# Altium schematic sheet [05] Ethernet.SchDoc("[05] Ethernet")
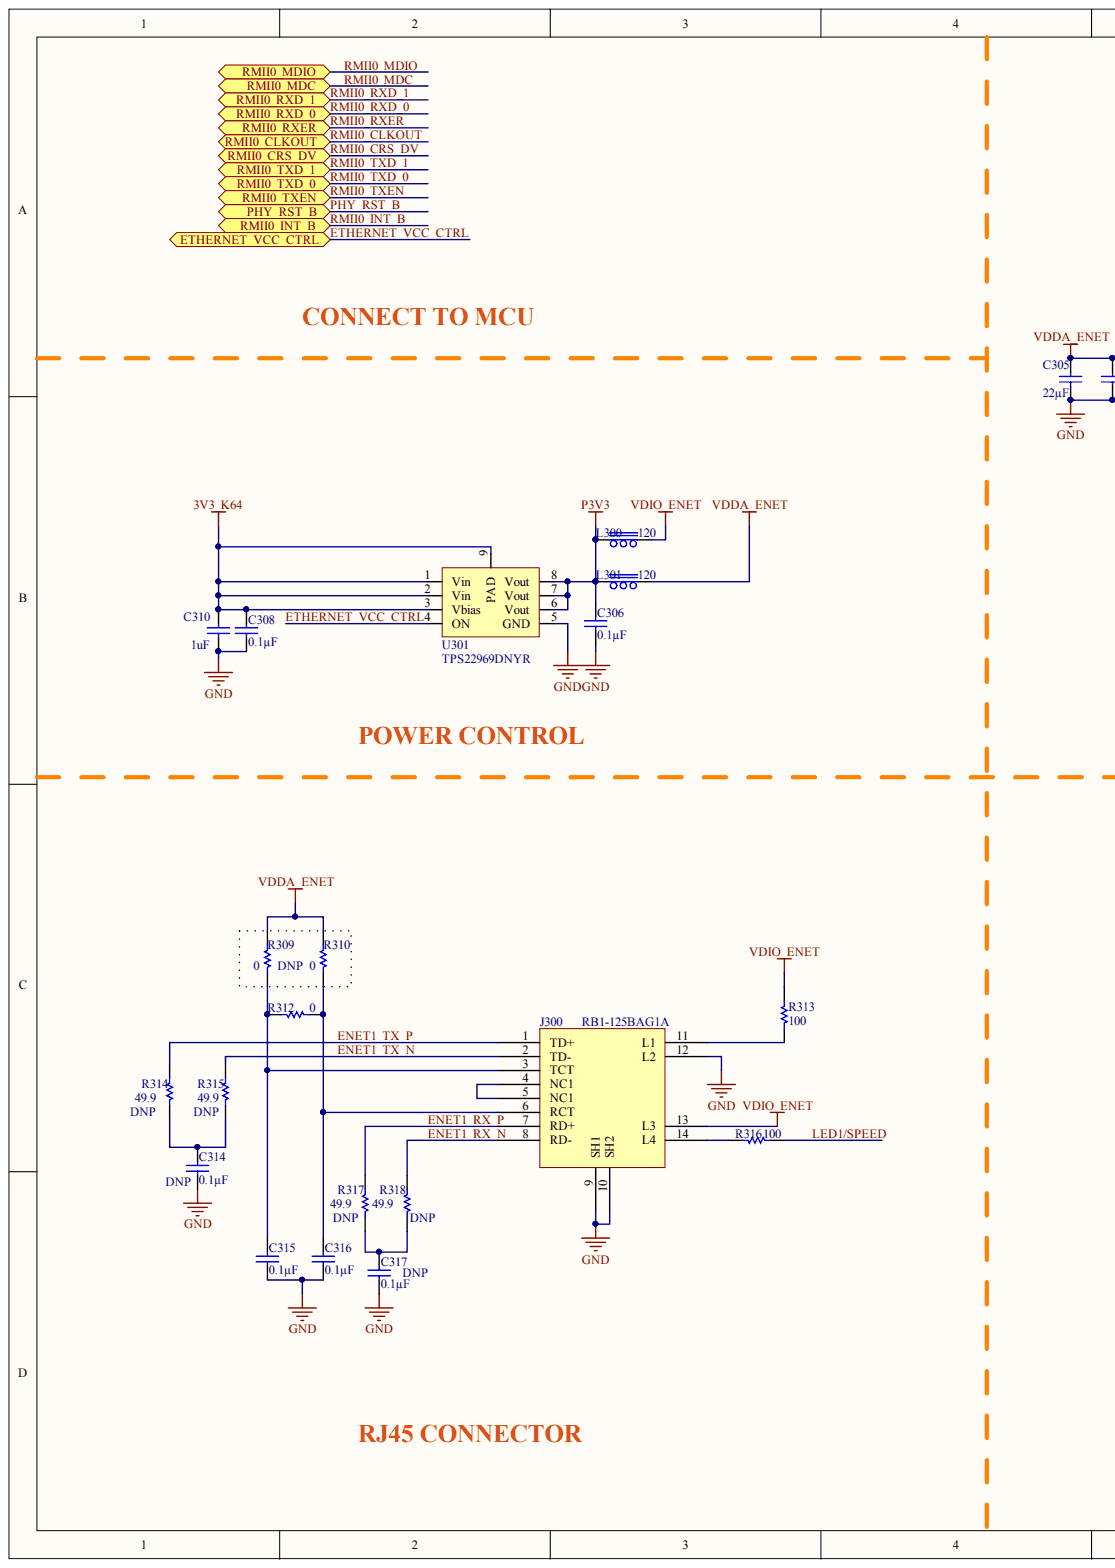
[diagram p0: [05] Ethernet.SchDoc, left]
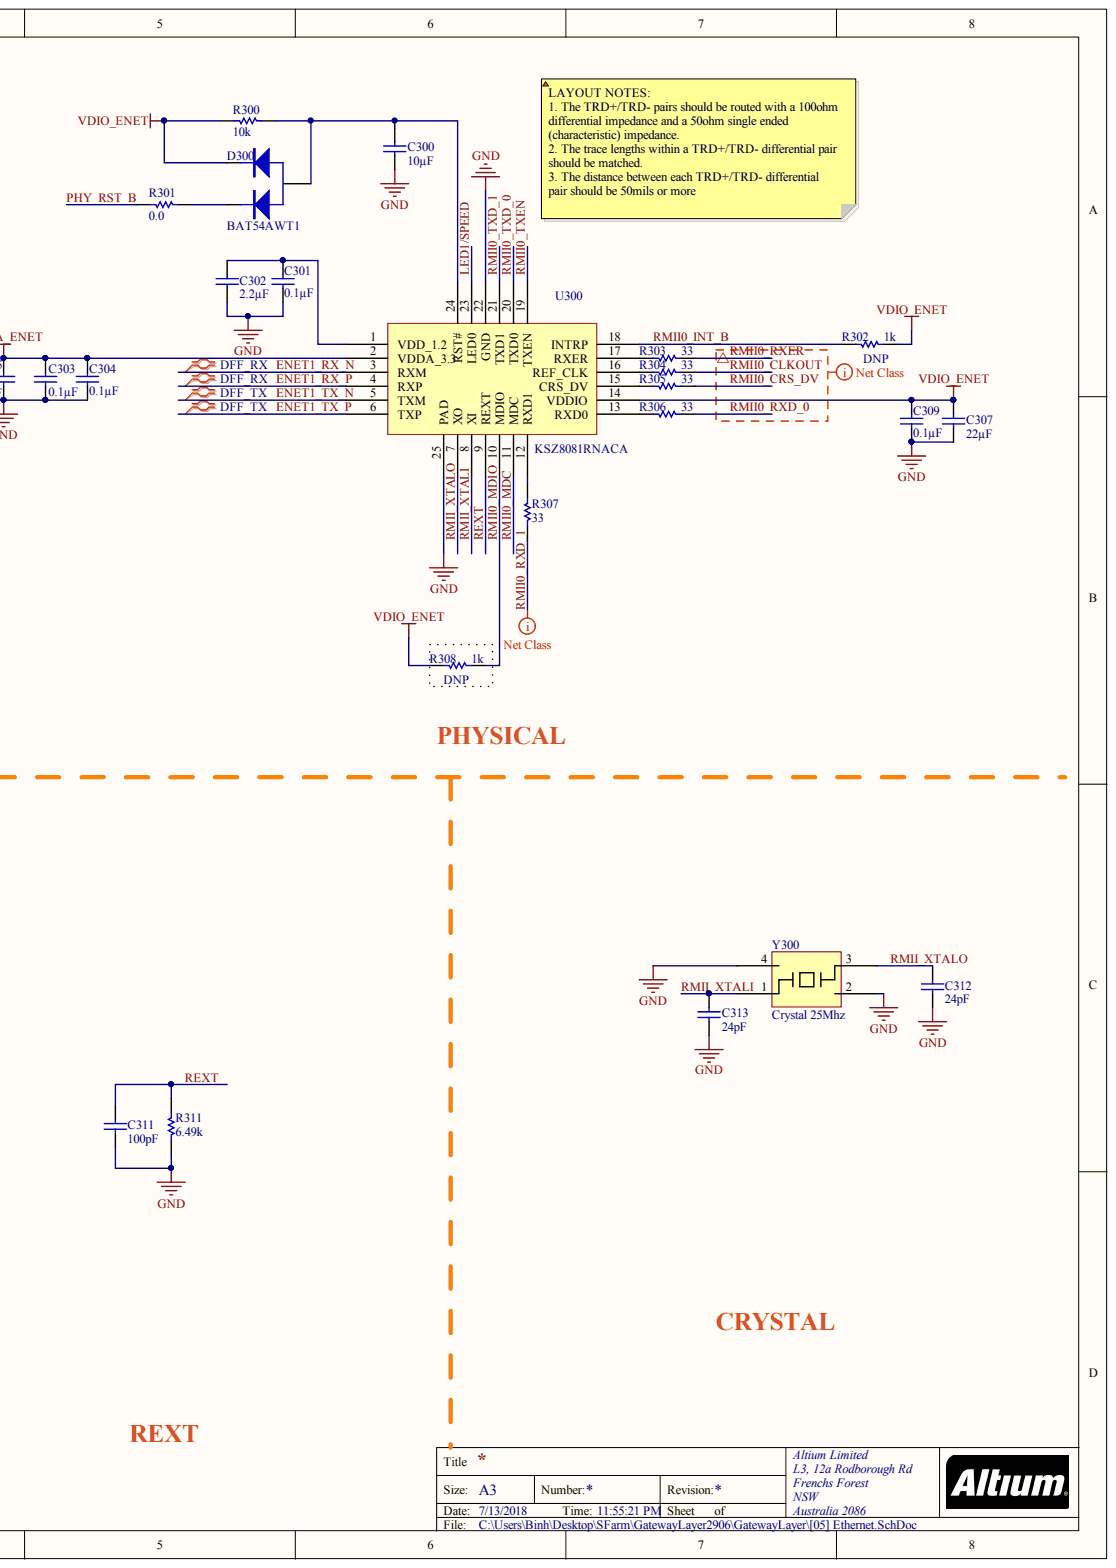
[diagram p1: [05] Ethernet.SchDoc, right]

## Parts
C300  10µF  [0603C]
C301  0.1µF  [0402C]
C302  2.2µF  [0402C]
C303  0.1µF  [0402C]
C304  0.1µF  [0402C]
C305  22µF  [0603C]
C306  0.1µF  [0402C]
C307  22µF  [0603C]
C308  0.1µF  [0402C]
C309  0.1µF  [0402C]
C310  1uF  [0402C]
C311  100pF  [0603C]
C312  24pF  [0402C]
C313  24pF  [0402C]
C314  0.1µF  [0402C]
C315  0.1µF  [0402C]
C316  0.1µF  [0402C]
C317  0.1µF  [0402C]
D300  BAT54AWT1  [SOT323]
J300  RB1-125BAG1A  [RJ45 Tranfomer]
L300  120  [0805F]
L301  120  [0805F]
R300  10k  [0603R]
R301  0.0  [0603R]
R302  1k  [0402R]
R303  33  [0402R]
R304  33  [0402R]
R305  33  [0402R]
R306  33  [0402R]
R307  33  [0402R]
R308  1k  [0402R]
R309  0  [0402R]
R310  0  [0402R]
R311  6.49k  [0603R]
R312  0  [0402R]
R313  100  [0603R]
R314  49.9  [0603R]
R315  49.9  [0603R]
R316  100  [0603R]  (value not drawn)
R317  49.9  [0603R]
R318  49.9  [0603R]
U300  KSZ8081RNACA  [QFN24x]
U301  TPS22969DNYR  [WSON8]
Y300  Crystal 25Mhz  [3225 cry]

## Ports
ETHERNET_VCC_CTRL, PHY_RST_B, RMII0_CLKOUT, RMII0_CRS_DV, RMII0_INT_B, RMII0_MDC, RMII0_MDIO, RMII0_RXD_0, RMII0_RXD_1, RMII0_RXER, RMII0_TXD_0, RMII0_TXD_1, RMII0_TXEN

## Nets
3V3_K64: C308.2 C310.2 U301.1(Vin) U301.2(Vin) U301.3(Vbias) U301.9(PAD)
GND: C300.1 C301.1 C302.1 C303.1 C304.1 C305.1 C306.1 C307.1 C308.1 C309.1 C310.1 C311.1 C312.1 C313.1 C314.1 C315.1 C316.1 C317.1 J300.9(SH1) J300.10(SH2) J300.12(L2) R311.1 U300.22(GND) U300.25(PAD) U301.5(GND) Y300.2 Y300.4
P3V3: C306.2 L300.1 L301.1 U301.6(Vout) U301.7(Vout) U301.8(Vout)
VDDA_ENET: C303.2 C304.2 C305.2 L301.2 R309.2 R310.2 U300.2(VDDA_3.3)
VDIO_ENET: C307.2 C309.2 D300.1 J300.13(L3) L300.2 R300.1 R302.2 R308.1 R313.2 U300.14(VDDIO)
ENET1_RX_N: J300.8(RD-) R318.2 U300.3(RXM)
ENET1_RX_P: J300.7(RD+) R317.2 U300.4(RXP)
ENET1_TX_N: J300.2(TD-) R315.2 U300.5(TXM)
ENET1_TX_P: J300.1(TD+) R314.2 U300.6(TXP)
ETHERNET_VCC_CTRL: U301.4(ON) ; port ETHERNET_VCC_CTRL
LED1/SPEED: R316.2 U300.23(LED0)
PHY_RST_B: R301.1 ; port PHY_RST_B
REXT: C311.2 R311.2 U300.9(REXT)
RMII0_CLKOUT: R304.2 ; port RMII0_CLKOUT
RMII0_CRS_DV: R305.2 ; port RMII0_CRS_DV
RMII0_INT_B: R302.1 U300.18(INTRP) ; port RMII0_INT_B
RMII0_MDC: U300.11(MDC) ; port RMII0_MDC
RMII0_MDIO: R308.2 U300.10(MDIO) ; port RMII0_MDIO
RMII0_RXD_0: R306.2 ; port RMII0_RXD_0
RMII0_RXD_1: R307.1 ; port RMII0_RXD_1
RMII0_RXER: R303.2 ; port RMII0_RXER
RMII0_TXD_0: U300.20(TXD0) ; port RMII0_TXD_0
RMII0_TXD_1: U300.21(TXD1) ; port RMII0_TXD_1
RMII0_TXEN: U300.19(TXEN) ; port RMII0_TXEN
RMII_XTALI: C313.2 U300.8(XI) Y300.1
RMII_XTALO: C312.2 U300.7(XO) Y300.3
NetC300_2: C300.2 D300.3 R300.2 U300.24(RST#)
NetC301_2: C301.2 C302.2 U300.1(VDD_1.2)
NetC314_2: C314.2 R314.1 R315.1
NetC315_2: C315.2 J300.3(TCT) R309.1 R312.2
NetC316_2: C316.2 J300.6(RCT) R310.1 R312.1
NetC317_2: C317.2 R317.1 R318.1
NetD300_2: D300.2 R301.2
NetJ300_4: J300.4(NC1) J300.5(NC1)
NetJ300_11: J300.11(L1) R313.1
NetJ300_14: J300.14(L4) R316.1
NetR303_1: R303.1 U300.17(RXER)
NetR304_1: R304.1 U300.16(REF_CLK)
NetR305_1: R305.1 U300.15(CRS_DV)
NetR306_1: R306.1 U300.13(RXD0)
NetR307_2: R307.2 U300.12(RXD1)
N00117:

## Library data of the parts
C300 = cap SMD: CAP CER 10UF 16V X6S 0603; Manufacturer: Taiyo Yuden; Manufacturer Part Number: EMK107BC6106MA-T; Tolerance: ±20%; Applications: SMPS Filtering; Category: Capacitors; Component Kind: Standard; ComponentLink1Description: http://www.yuden.co.jp/productdata/catalog/en/mlcc06_e.pdf; ComponentLink1URL: http://www.yuden.co.jp/productdata/catalog/en/mlcc06_e.pdf; Failure Rate: -; Features: -; Height - Seated (Max): -; Lead Spacing: -; Lead Style: -; Mounting Type: Surface Mount, MLCC; Operating Temperature: -55°C ~ 105°C; Package / Case: 0603 (1608 Metric); Packaging: Cut Tape (CT); Part Status: Active; Pricing 1: 1=0.46, 10=0.32, 100=0.192, 500=0.14402, 1000=0.12162 (USD); Ratings: -; RoHS: RoHS Compliant; Size / Dimension: 0.063" L x 0.031" W (1.60mm x 0.80mm); Stock 1: 23688; Supplier 1: Digi-Key; Supplier Part Number 1: 587-4867-1-ND; Temperature Coefficient: X6S; Thickness (Max): 0.039" (1.00mm); Voltage - Rated: 16V; Library Name: BinhLib.IntLib
C301 C303 C304 C306 C308 C309 C314-C317 = CAP0.1u0402: CAP CER 0.1UF 10V X7R 0402; Manufacturer: Taiyo Yuden; Manufacturer Part Number: LMK105B7104KVHF; Tolerance: ±10%; Applications: Automotive; Capacitance: 0.1µF; Category: Capacitors; Component Kind: Standard; ComponentLink1Description: http://www.yuden.co.jp/productdata/catalog/en/mlcc_all_hq_e.pdf; ComponentLink1URL: http://www.yuden.co.jp/productdata/catalog/en/mlcc_all_hq_e.pdf; Features: -; Height - Seated (Max): -; Lead Spacing: -; Lead Style: -; Mounting Type: Surface Mount, MLCC; Operating Temperature: -55°C ~ 125°C; Package / Case: 0402 (1005 Metric); Packaging: Cut Tape (CT); Part Status: Active; Pricing 1: 1=0.1, 10=0.05, 100=0.0223, 500=0.01594, 1000=0.01252, 2500=0.01138, 5000=0.01047 (USD); Ratings: AEC-Q200; RoHS: RoHS Compliant; Size / Dimension: 0.039" L x 0.020" W (1.00mm x 0.50mm); Stock 1: 23930; Supplier 1: Digi-Key; Supplier Part Number 1: 587-3769-1-ND; Temperature Coefficient: X7R; Thickness (Max): 0.022" (0.55mm); Voltage - Rated: 10V; Library Name: BinhLib.IntLib
C302 = cap2.2u0402: CAP CER 2.2UF 16V X5R 0402; Manufacturer: Taiyo Yuden; Manufacturer Part Number: EMK105ABJ225MV-F; Tolerance: ±20%; Applications: General Purpose; Capacitance: 2.2µF; Category: Capacitors; Component Kind: Standard; ComponentLink1Description: http://ds.yuden.co.jp/TYCOMPAS/ut/detail.do?productNo=EMK105ABJ225MV-F&fileName=EMK105ABJ225MV-F_SS&mode=specSheetDownload; ComponentLink1URL: http://ds.yuden.co.jp/TYCOMPAS/ut/detail.do?productNo=EMK105ABJ225MV-F&fileName=EMK105ABJ225MV-F_SS&mode=specSheetDownload; Features: -; Height - Seated (Max): -; Lead Spacing: -; Lead Style: -; Mounting Type: Surface Mount, MLCC; Operating Temperature: -55°C ~ 85°C; Package / Case: 0402 (1005 Metric); Packaging: Cut Tape (CT); Part Status: Active; Pricing 1: 1=0.27, 10=0.187, 100=0.1062, 500=0.07498, 1000=0.0656, 2500=0.06248, 5000=0.05779 (USD); Ratings: -; RoHS: RoHS Compliant; Size / Dimension: 0.039" L x 0.020" W (1.00mm x 0.50mm); Stock 1: 43595; Supplier 1: Digi-Key; Supplier Part Number 1: 587-3302-1-ND; Temperature Coefficient: X5R; Thickness (Max): 0.022" (0.55mm); Voltage - Rated: 16V; Library Name: BinhLib.IntLib
C305 C307 = cap SMD: CAP CER 22UF 10V X5R 0603; Manufacturer: Taiyo Yuden; Manufacturer Part Number: LMK107BBJ226MA-T; Tolerance: ±20%; Applications: SMPS Filtering; Category: Capacitors; Component Kind: Standard; ComponentLink1Description: http://ds.yuden.co.jp/TYCOMPAS/ut/detail.do?productNo=LMK107BBJ226MA-T&fileName=LMK107BBJ226MA-T_SS&mode=specSheetDownload; ComponentLink1URL: http://ds.yuden.co.jp/TYCOMPAS/ut/detail.do?productNo=LMK107BBJ226MA-T&fileName=LMK107BBJ226MA-T_SS&mode=specSheetDownload; Failure Rate: -; Features: -; Height - Seated (Max): -; Lead Spacing: -; Lead Style: -; Mounting Type: Surface Mount, MLCC; Operating Temperature: -55°C ~ 85°C; Package / Case: 0603 (1608 Metric); Packaging: Cut Tape (CT); Part Status: Active; Pricing 1: 1=0.49, 10=0.345, 100=0.2271, 500=0.16826, 1000=0.14302 (USD); Ratings: -; RoHS: RoHS Compliant; Size / Dimension: 0.063" L x 0.031" W (1.60mm x 0.80mm); Stock 1: 11956; Supplier 1: Digi-Key; Supplier Part Number 1: 587-4913-1-ND; Temperature Coefficient: X5R; Thickness (Max): 0.039" (1.00mm); Voltage - Rated: 10V; Library Name: BinhLib.IntLib
C310 = cap SMD: CAP CER 1UF 10V X6S 0402; Manufacturer: Taiyo Yuden; Manufacturer Part Number: LMK105C6105KV-F; Tolerance: ±10%; Applications: General Purpose; Category: Capacitors; Component Kind: Standard; ComponentLink1Description: http://www.yuden.co.jp/productdata/catalog/en/mlcc01_e.pdf; ComponentLink1URL: http://www.yuden.co.jp/productdata/catalog/en/mlcc01_e.pdf; Features: -; Height - Seated (Max): -; Lead Spacing: -; Lead Style: -; Mounting Type: Surface Mount, MLCC; Operating Temperature: -55°C ~ 105°C; Package / Case: 0402 (1005 Metric); Packaging: Cut Tape (CT); Part Status: Active; Pricing 1: 1=0.13, 10=0.096, 100=0.0451, 500=0.03164, 1000=0.02619, 2500=0.02438, 5000=0.0223 (USD); Ratings: -; RoHS: RoHS Compliant; Size / Dimension: 0.039" L x 0.020" W (1.00mm x 0.50mm); Stock 1: 11404; Supplier 1: Digi-Key; Supplier Part Number 1: 587-3409-1-ND; Temperature Coefficient: X6S; Thickness (Max): 0.022" (0.55mm); Voltage - Rated: 10V; Library Name: BinhLib.IntLib
C311 = cap SMD: CAP CER 100PF 250V NP0 0603; Manufacturer: Taiyo Yuden; Manufacturer Part Number: QVS107CG101JCHT; Temperature Coefficient: C0G, NP0; Tolerance: ±5%; Applications: Automotive; Capacitance: 100pF; Category: Capacitors; Component Kind: Standard; Family: Ceramic Capacitors; Features: -; Height - Seated (Max): -; Lead Spacing: -; Lead Style: -; Mounting Type: Surface Mount, MLCC; Operating Temperature: -55°C ~ 125°C; Package / Case: 0603 (1608 Metric); Packaging: Tape &amp; Reel (TR); Pricing 1: 1=0.71, 10=0.598, 50=0.4286, 100=0.3751, 250=0.2947, 500=0.2679, 1000=0.2143 (USD); Ratings: -; Series: V; Size / Dimension: 0.063" L x 0.031" W (1.60mm x 0.80mm); Stock 1: 7748; Supplier 1: Digikey; Supplier Part Number 1: 587-4439-6-ND; Thickness (Max): 0.031" (0.80mm); Voltage - Rated: 250V; Library Name: BinhLib.IntLib
C312 C313 = cap SMD: CAP CER 24PF 50V NP0 0402; Manufacturer: Taiyo Yuden; Manufacturer Part Number: UMK105CG240JV-F; Temperature Coefficient / Code: C0G; Tolerance: 5 %; Capacitance: 24 pF; Case Code - in: 0402; Case Code - mm: 1005; Component Kind: Standard; ComponentLink1Description: http://www.mouser.com/ds/2/396/mlcc02_e-9198.pdf; ComponentLink1URL: http://www.mouser.com/ds/2/396/mlcc02_e-9198.pdf; Dimensions: 0.5 mm W x 1 mm L x 0.5 mm H; Operating Temperature Range: - 55 C to + 125 C; Package / Case: 0402 (1005 metric); Packaging: Reel; Pricing 1: 10000=0.0034 (USD); Product: General Type MLCCs; RoHS: Details; Series: M; Stock 1: 0; Supplier 1: Digikey; Supplier Part Number 1: UMK105CG240JV-F-ND; Termination Style: SMD/SMT; Voltage Rating: 50 V; Library Name: BinhLib.IntLib
D300 = diodes barrier: DIODE ARRAY SCHOTTKY 30V SOT323; Manufacturer: ON Semiconductor; Manufacturer Part Number: BAT54AWT1G; Current - Average Rectified (Io) (per Diode): 200mA (DC); Current - Reverse Leakage @ Vr: 2µA @ 25V; Diode Configuration: 1 Pair Common Anode; Speed: Small Signal =< 200mA (Io), Any Speed; Voltage - Forward (Vf) (Max) @ If: 800mV @ 100mA; Category: Discrete Semiconductor Products; Component Kind: Standard; ComponentLink1Description: http://www.onsemi.com/pub_link/Collateral/BAT54AWT1-D.PDF; ComponentLink1URL: http://www.onsemi.com/pub_link/Collateral/BAT54AWT1-D.PDF; Diode Type: Schottky; Mounting Type: Surface Mount; Operating Temperature - Junction: -55°C ~ 125°C; Package / Case: SC-70, SOT-323; Packaging: Cut Tape (CT); Part Status: Active; Pricing 1: 1=0.3, 10=0.248, 100=0.1313, 500=0.08638, 1000=0.05874 (USD); RoHS: RoHS Compliant; Stock 1: 0; Supplier 1: Digi-Key; Supplier Device Package: SOT-323; Supplier Part Number 1: BAT54AWT1GOSCT-ND; Library Name: BinhLib.IntLib
J300 = RJ45: CONN MAGJACK 1PORT 100 BASE-T; Manufacturer: WIZnet; LED Color: Green - Yellow; Number of Cores per Jack: 4; Number of Ports: 1; Other Names: 1278-1011  RB1125BAG1A; Shielding: Shielded, EMI Finger; Shield Material: Stainless Steel; Tab Direction: Down; Applications: 10/100 Base-T, AutoMDIX; Category: Connectors, Interconnects; Component Kind: Standard; ComponentLink1Description: https://datasheet.ciiva.com/16480/rb1-125bag1a-16480451.pdf; ComponentLink1URL: https://datasheet.ciiva.com/16480/rb1-125bag1a-16480451.pdf; ComponentLink2Description: https://datasheet.ciiva.com/19455/2132875-19455478.pdf; ComponentLink2URL: https://datasheet.ciiva.com/19455/2132875-19455478.pdf; ComponentLink3Description: https://datasheet.ciiva.com/6930/rb1-125bag1a-approvalsheet-6930969.pdf; ComponentLink3URL: https://datasheet.ciiva.com/6930/rb1-125bag1a-approvalsheet-6930969.pdf; ComponentLink4Description: https://datasheet.ciiva.com/15367/rb1-125bag1a111-00-224696-15367787.pdf; ComponentLink4URL: https://datasheet.ciiva.com/15367/rb1-125bag1a111-00-224696-15367787.pdf; Connector Type: RJ45; Contact Finish: Gold; Contact Finish Thickness: Flash; Contact Material: Phosphor Bronze; Family: Modular Connectors - Jacks With Magnetics; Features: Board Lock; Height Above Board: 0.525" (13.33mm); Housing Material: Thermoplastic; Manufacturer Part Number: RB1-125BAG1A; Mounting Type: Through Hole; Number of Rows: 1; Online Catalog: WIZnet; Operating Temperature: 0°C ~ 70°C; Orientation: 90° Angle (Right); Packaging: Bulk; Pricing 1: 1=2.55, 10=2.448, 25=2.244, 50=2.142, 100=2.04, 250=1.785, 500=1.734, 1000=1.56 (USD); Series: -; Standard Package: 420; Stock 1: 25601; Supplier 1: Digikey; Supplier Part Number 1: 1278-1011-ND; Termination: Solder; Library Name: BinhLib.IntLib
L300 L301 = Ferrite Bead: Manufacturer: MURATA; Manufacturer Part Number: BLM18PG121SN1D; DC Current Rating: 2; DC Resistance Max: 0.05; Ferrite Case Style: 0603 [1608 Metric]; Impedance Tolerance: 25%; Product Range: BLM18; Automotive Qualifications Standard: -; Component Kind: Standard; ComponentLink1Description: http://www.farnell.com/datasheets/1740433.pdf; ComponentLink1URL: http://www.farnell.com/datasheets/1740433.pdf; ComponentLink2Description: http://www.farnell.com/datasheets/5148.pdf; ComponentLink2URL: http://www.farnell.com/datasheets/5148.pdf; Packaging: Each; Pricing 1: 1=0.039, 50=0.036, 100=0.029, 500=0.026, 1000=0.023, 2500=0.022, 5000=0.02, 10000=0.019 (USD); RoHS: YES; Stock 1: 28827; Supplier 1: Newark; Supplier Part Number 1: 73M9109; Library Name: BinhLib.IntLib
R300 = RES10k0603: RES SMD 10K OHM 1% 1/10W 0603; Manufacturer: Panasonic Electronic Components; Manufacturer Part Number: ERJ-3EKF1002V; Power (Watts): 0.1W, 1/10W; Temperature Coefficient: ±100ppm/°C; Category: Resistors; Component Kind: Standard; ComponentLink1Description: https://industrial.panasonic.com/cdbs/www-data/pdf/RDA0000/AOA0000C298.pdf; ComponentLink1URL: https://industrial.panasonic.com/cdbs/www-data/pdf/RDA0000/AOA0000C298.pdf; Composition: Thick Film; Failure Rate: -; Features: Automotive AEC-Q200; Height - Seated (Max): 0.022" (0.55mm); Operating Temperature: -55°C ~ 155°C; Package / Case: 0603 (1608 Metric); Packaging: Digi-Reel®; Part Status: Active; Pricing 1: 1=0.1, 10=0.021, 100=0.0087, 1000=0.00389, 2500=0.00338 (USD); Resistance (Ohms): 10k; RoHS: RoHS Compliant; Size / Dimension: 0.063" L x 0.031" W (1.60mm x 0.80mm); Stock 1: 0; Supplier 1: Digi-Key; Supplier Device Package: 0603; Supplier Part Number 1: P10.0KHDKR-ND; Tolerance: ±1%; Library Name: BinhLib.IntLib
R301 = RES00603: RES SMD 0.0OHM JUMPER 1/10W 0603; Manufacturer: Panasonic Electronic Components; Manufacturer Part Number: ERJ-3GEY0R00V; Power (Watts): 0.1W, 1/10W; Category: Resistors; Component Kind: Standard; ComponentLink1Description: http://industrial.panasonic.com/www-cgi/jvcr13pz.cgi?E+PZ+3+AOA0001+ERJ3GEY0R00V+7+WW; ComponentLink1URL: http://industrial.panasonic.com/www-cgi/jvcr13pz.cgi?E+PZ+3+AOA0001+ERJ3GEY0R00V+7+WW; Composition: Thick Film; Failure Rate: -; Features: Automotive AEC-Q200; Height - Seated (Max): 0.022" (0.55mm); Operating Temperature: -55°C ~ 155°C; Package / Case: 0603 (1608 Metric); Packaging: Cut Tape (CT); Part Status: Active; Pricing 1: 1=0.1, 10=0.016, 25=0.0116, 50=0.0088, 100=0.0065, 250=0.00496, 500=0.00396, 1000=0.00292 (USD); Resistance (Ohms): 0.0; RoHS: RoHS Compliant; Size / Dimension: 0.063" L x 0.031" W (1.60mm x 0.80mm); Stock 1: 8597757; Supplier 1: Digi-Key; Supplier Device Package: 0603; Supplier Part Number 1: P0.0GCT-ND; Temperature Coefficient: -; Tolerance: Jumper; Library Name: BinhLib.IntLib
R302 R308 = RES1k0402: RES SMD 1K OHM 1% 1/10W 0402; Manufacturer: Panasonic Electronic Components; Manufacturer Part Number: ERJ-2RKF1001X; Power (Watts): 0.1W, 1/10W; Temperature Coefficient: ±100ppm/°C; Category: Resistors; Component Kind: Standard; ComponentLink1Description: https://industrial.panasonic.com/cdbs/www-data/pdf/RDA0000/AOA0000C298.pdf; ComponentLink1URL: https://industrial.panasonic.com/cdbs/www-data/pdf/RDA0000/AOA0000C298.pdf; Composition: Thick Film; Failure Rate: -; Features: Automotive AEC-Q200; Height - Seated (Max): 0.016" (0.40mm); Operating Temperature: -55°C ~ 155°C; Package / Case: 0402 (1005 Metric); Packaging: Cut Tape (CT); Part Status: Active; Pricing 1: 1=0.1, 10=0.028, 100=0.0113, 1000=0.00509, 2500=0.00442, 5000=0.00365 (USD); Resistance (Ohms): 1k; RoHS: RoHS Compliant; Size / Dimension: 0.039" L x 0.020" W (1.00mm x 0.50mm); Stock 1: 0; Supplier 1: Digi-Key; Supplier Device Package: 0402; Supplier Part Number 1: P1.00KLCT-ND; Tolerance: ±1%; Library Name: BinhLib.IntLib
R303-R307 = RES330402: RES SMD 33 OHM 1% 1/10W 0402; Manufacturer: Panasonic Electronic Components; Manufacturer Part Number: ERJ-2RKF33R0X; Power (Watts): 0.1W, 1/10W; Temperature Coefficient: ±100ppm/°C; Category: Resistors; Component Kind: Standard; ComponentLink1Description: http://media.digikey.com/pdf/Data%20Sheets/Panasonic%20Electronic%20Components/ERJ%20Series_Precision.pdf; ComponentLink1URL: http://media.digikey.com/pdf/Data%20Sheets/Panasonic%20Electronic%20Components/ERJ%20Series_Precision.pdf; Composition: Thick Film; Failure Rate: -; Features: Automotive AEC-Q200; Height - Seated (Max): 0.016" (0.40mm); Operating Temperature: -55°C ~ 155°C; Package / Case: 0402 (1005 Metric); Packaging: Cut Tape (CT); Part Status: Active; Pricing 1: 1=0.1, 10=0.028, 100=0.0113, 1000=0.00509, 2500=0.00442, 5000=0.00365 (USD); Resistance (Ohms): 33; RoHS: RoHS Compliant; Size / Dimension: 0.039" L x 0.020" W (1.00mm x 0.50mm); Stock 1: 104778; Supplier 1: Digi-Key; Supplier Device Package: 0402; Supplier Part Number 1: P33.0LCT-ND; Tolerance: ±1%; Library Name: BinhLib.IntLib
R309 R310 R312 = RES00603: RES SMD 0.0OHM JUMPER 1/10W 0402; Manufacturer: Panasonic Electronic Components; Manufacturer Part Number: ERJ-2GE0R00X; Power (Watts): 0.1W, 1/10W; Category: Resistors; Component Kind: Standard; ComponentLink1Description: http://industrial.panasonic.com/www-cgi/jvcr13pz.cgi?E+PZ+3+AOA0001+ERJ2GE0R00X+7+WW; ComponentLink1URL: http://industrial.panasonic.com/www-cgi/jvcr13pz.cgi?E+PZ+3+AOA0001+ERJ2GE0R00X+7+WW; Composition: Thick Film; Failure Rate: -; Features: Automotive AEC-Q200; Height - Seated (Max): 0.016" (0.40mm); Operating Temperature: -55°C ~ 155°C; Package / Case: 0402 (1005 Metric); Packaging: Cut Tape (CT); Part Status: Active; Pricing 1: 1=0.1, 10=0.016, 25=0.0116, 50=0.0088, 100=0.0065, 250=0.00496, 500=0.00396, 1000=0.00292, 5000=0.00209 (USD); RoHS: RoHS Compliant; Size / Dimension: 0.039" L x 0.020" W (1.00mm x 0.50mm); Stock 1: 3982454; Supplier 1: Digi-Key; Supplier Device Package: 0402; Supplier Part Number 1: P0.0JCT-ND; Temperature Coefficient: -; Tolerance: Jumper; Library Name: BinhLib.IntLib
R311 = RES1000603: RES SMD 6.49KOHM 0.1% 1/10W 0603; Manufacturer: Panasonic Electronic Components; Manufacturer Part Number: ERA-3AEB6491V; Power (Watts): 0.1W, 1/10W; Temperature Coefficient: ±25ppm/°C; Category: Resistors; Component Kind: Standard; ComponentLink1Description: https://industrial.panasonic.com/cdbs/www-data/pdf/RDM0000/AOA0000C307.pdf; ComponentLink1URL: https://industrial.panasonic.com/cdbs/www-data/pdf/RDM0000/AOA0000C307.pdf; Composition: Thin Film; Failure Rate: -; Features: Automotive AEC-Q200; Height - Seated (Max): 0.022" (0.55mm); Operating Temperature: -55°C ~ 155°C; Package / Case: 0603 (1608 Metric); Packaging: Cut Tape (CT); Part Status: Active; Pricing 1: 1=0.35, 10=0.3, 100=0.1172, 1000=0.04906, 2500=0.04498 (USD); Resistance (Ohms): 6.49k; RoHS: RoHS Compliant; Size / Dimension: 0.063" L x 0.031" W (1.60mm x 0.80mm); Stock 1: 16504; Supplier 1: Digi-Key; Supplier Device Package: 0603; Supplier Part Number 1: P6.49KDBCT-ND; Tolerance: ±0.1%; Library Name: BinhLib.IntLib
R313 R316 = RES1000603: RES SMD 100 OHM 1% 1/10W 0603; Manufacturer: Panasonic Electronic Components; Manufacturer Part Number: ERJ-3EKF1000V; Power (Watts): 0.1W, 1/10W; Temperature Coefficient: ±100ppm/°C; Category: Resistors; Component Kind: Standard; ComponentLink1Description: https://industrial.panasonic.com/cdbs/www-data/pdf/RDA0000/AOA0000C298.pdf; ComponentLink1URL: https://industrial.panasonic.com/cdbs/www-data/pdf/RDA0000/AOA0000C298.pdf; Composition: Thick Film; Failure Rate: -; Features: Automotive AEC-Q200; Height - Seated (Max): 0.022" (0.55mm); Operating Temperature: -55°C ~ 155°C; Package / Case: 0603 (1608 Metric); Packaging: Cut Tape (CT); Part Status: Active; Pricing 1: 1=0.1, 10=0.021, 100=0.0087, 1000=0.00389, 2500=0.00338 (USD); Resistance (Ohms): 100; RoHS: RoHS Compliant; Size / Dimension: 0.063" L x 0.031" W (1.60mm x 0.80mm); Stock 1: 648504; Supplier 1: Digi-Key; Supplier Device Package: 0603; Supplier Part Number 1: P100HCT-ND; Tolerance: ±1%; Library Name: BinhLib.IntLib
R314 R315 R317 R318 = Resistor: RES SMD 49.9 OHM 1% 1/10W 0603; Manufacturer: Panasonic Electronic Components; Manufacturer Part Number: ERJ-3EKF49R9V; Power (Watts): 0.1W, 1/10W; Temperature Coefficient: ±100ppm/°C; Category: Resistors; Component Kind: Standard; ComponentLink1Description: http://media.digikey.com/pdf/Data%20Sheets/Panasonic%20Electronic%20Components/ERJ%20Series_Precision.pdf; ComponentLink1URL: http://media.digikey.com/pdf/Data%20Sheets/Panasonic%20Electronic%20Components/ERJ%20Series_Precision.pdf; Composition: Thick Film; Failure Rate: -; Features: Automotive AEC-Q200; Height - Seated (Max): 0.022" (0.55mm); Operating Temperature: -55°C ~ 155°C; Package / Case: 0603 (1608 Metric); Packaging: Cut Tape (CT); Part Status: Active; Pricing 1: 1=0.1, 10=0.021, 100=0.0087, 1000=0.00389, 2500=0.00338 (USD); Resistance (Ohms): 49.9; RoHS: RoHS Compliant; Size / Dimension: 0.063" L x 0.031" W (1.60mm x 0.80mm); Stock 1: 0; Supplier 1: Digi-Key; Supplier Device Package: 0603; Supplier Part Number 1: P49.9HCT-ND; Tolerance: ±1%; Library Name: BinhLib.IntLib
U300 = KSZ8081RNACA: IC TXRX ETHERNET 24QFN; Manufacturer: Microchip Technology; Manufacturer Part Number: KSZ8081RNACA-TR; Duplex: Full; Number of Drivers/Receivers: 1/1; Protocol: RMII; Voltage - Supply: 1.8V, 2.5V, 3.3V; Category: Integrated Circuits (ICs); Component Kind: Standard; ComponentLink1Description: http://www.microchip.com/mymicrochip/filehandler.aspx?ddocname=en581592; ComponentLink1URL: http://www.microchip.com/mymicrochip/filehandler.aspx?ddocname=en581592; Data Rate: -; Mounting Type: Surface Mount; Operating Temperature: 0°C ~ 70°C; Package / Case: 24-VFQFN Exposed Pad; Packaging: Cut Tape (CT); Part Status: Active; Pricing 1: 1=1.04, 25=0.8652, 100=0.8613 (USD); RoHS: RoHS Compliant; Stock 1: 26739; Supplier 1: Digi-Key; Supplier Device Package: 24-QFN (4x4); Supplier Part Number 1: 576-4173-1-ND; Type: Transceiver; Library Name: BinhLib.IntLib
U301 = TPS22969: IC LOAD SWITCH 6A 8WSON; Manufacturer: Texas Instruments; Input Type: Non-Inverting; Interface: On/Off; Number of Outputs: 1; Output Configuration: High Side; Output Type: N-Channel; Ratio - Input: 1:1; Rds On (Typ): 4.4 mOhm; Switch Type: General Purpose; Voltage - Load: 2.5 V ~ 5.5 V; Voltage - Supply (Vcc/Vdd): 0.8 V ~ 5.5 V; Category: Integrated Circuits (ICs); Component Kind: Standard; ComponentLink1Description: http://www.ti.com/lit/ds/symlink/tps22969.pdf; ComponentLink1URL: http://www.ti.com/lit/ds/symlink/tps22969.pdf; Current - Output (Max): 6A; Fault Protection: -; Features: Load Discharge, Slew Rate Controlled; Manufacturer Part Number: TPS22969DNYR; Operating Temperature: -40°C ~ 125°C (TJ); Package / Case: 8-WDFN Exposed Pad; Packaging: Cut Tape (CT); Part Status: Active; Pricing 1: 1=1.53, 10=1.368, 100=1.0665, 500=0.882, 1000=0.5625 (USD); RoHS: RoHS Compliant; Stock 1: 3303; Supplier 1: Digi-Key; Supplier Device Package: 8-WSON (3x3); Supplier Part Number 1: 296-40721-1-ND; Library Name: BinhLib.IntLib
Y300 = Crystal 25Mhz: CRYSTAL 25.0000MHZ 18PF SMD; Manufacturer: CTS-Frequency Controls; Manufacturer Part Number: 403I35D25M00000; ESR (Equivalent Series Resistance): 60 Ohm; Frequency Stability: ±50ppm; Frequency Tolerance: ±30ppm; Operating Mode: Fundamental; Category: Crystals, Oscillators, Resonators; Component Kind: Standard; ComponentLink1Description: http://www.ctscorp.com/wp-content/uploads/403.pdf; ComponentLink1URL: http://www.ctscorp.com/wp-content/uploads/403.pdf; Frequency: 25MHz; Height - Seated (Max): 0.031" (0.80mm); Load Capacitance: 18pF; Mounting Type: Surface Mount; Operating Temperature: -40°C ~ 85°C; Package / Case: 4-SMD, No Lead; Packaging: Cut Tape (CT); Part Status: Active; Pricing 1: 1=0.92, 10=0.81, 50=0.7568, 100=0.6688, 500=0.6336, 1000=0.528 (USD); Ratings: -; RoHS: RoHS Compliant; Size / Dimension: 0.126" L x 0.098" W (3.20mm x 2.50mm); Stock 1: 4278; Supplier 1: Digi-Key; Supplier Part Number 1: CTX1224CT-ND; Type: MHz Crystal; Library Name: BinhLib.IntLib
